# Altium schematic sheet 03_STM32_MCU_POWER.SchDoc(03_STM32_MCU_POWER)
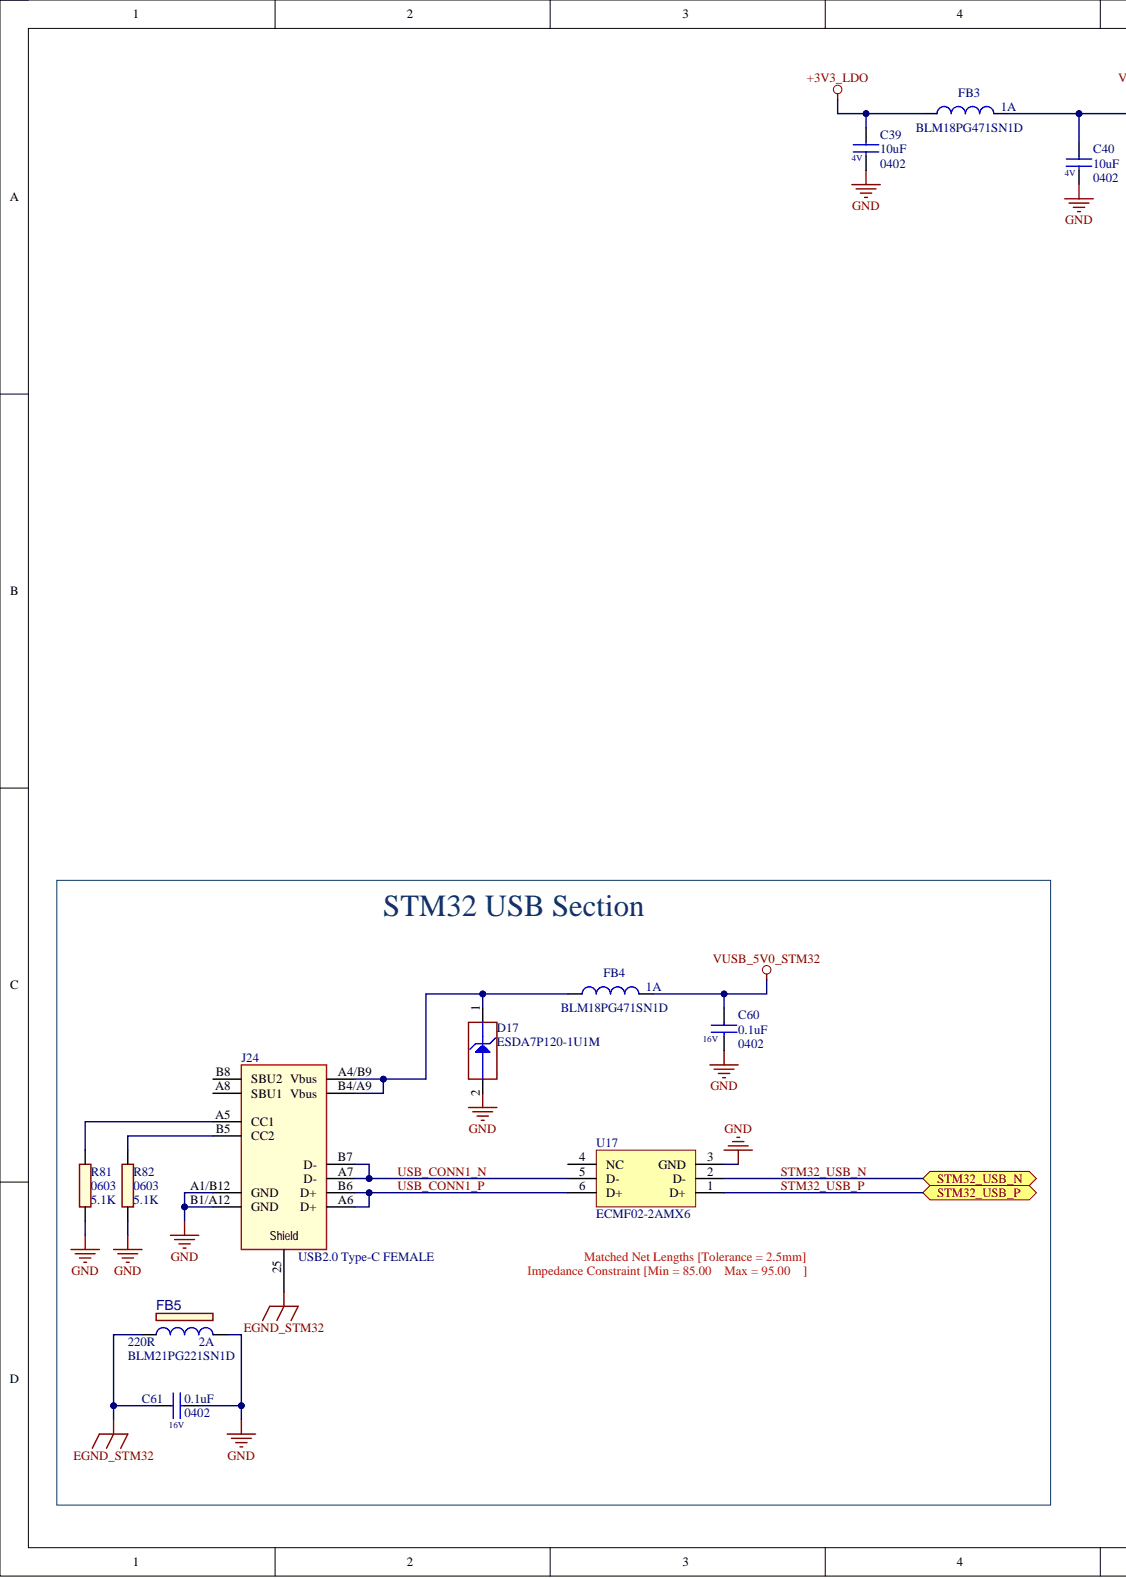
[diagram p0: 03_STM32_MCU_POWER.SchDoc, left]
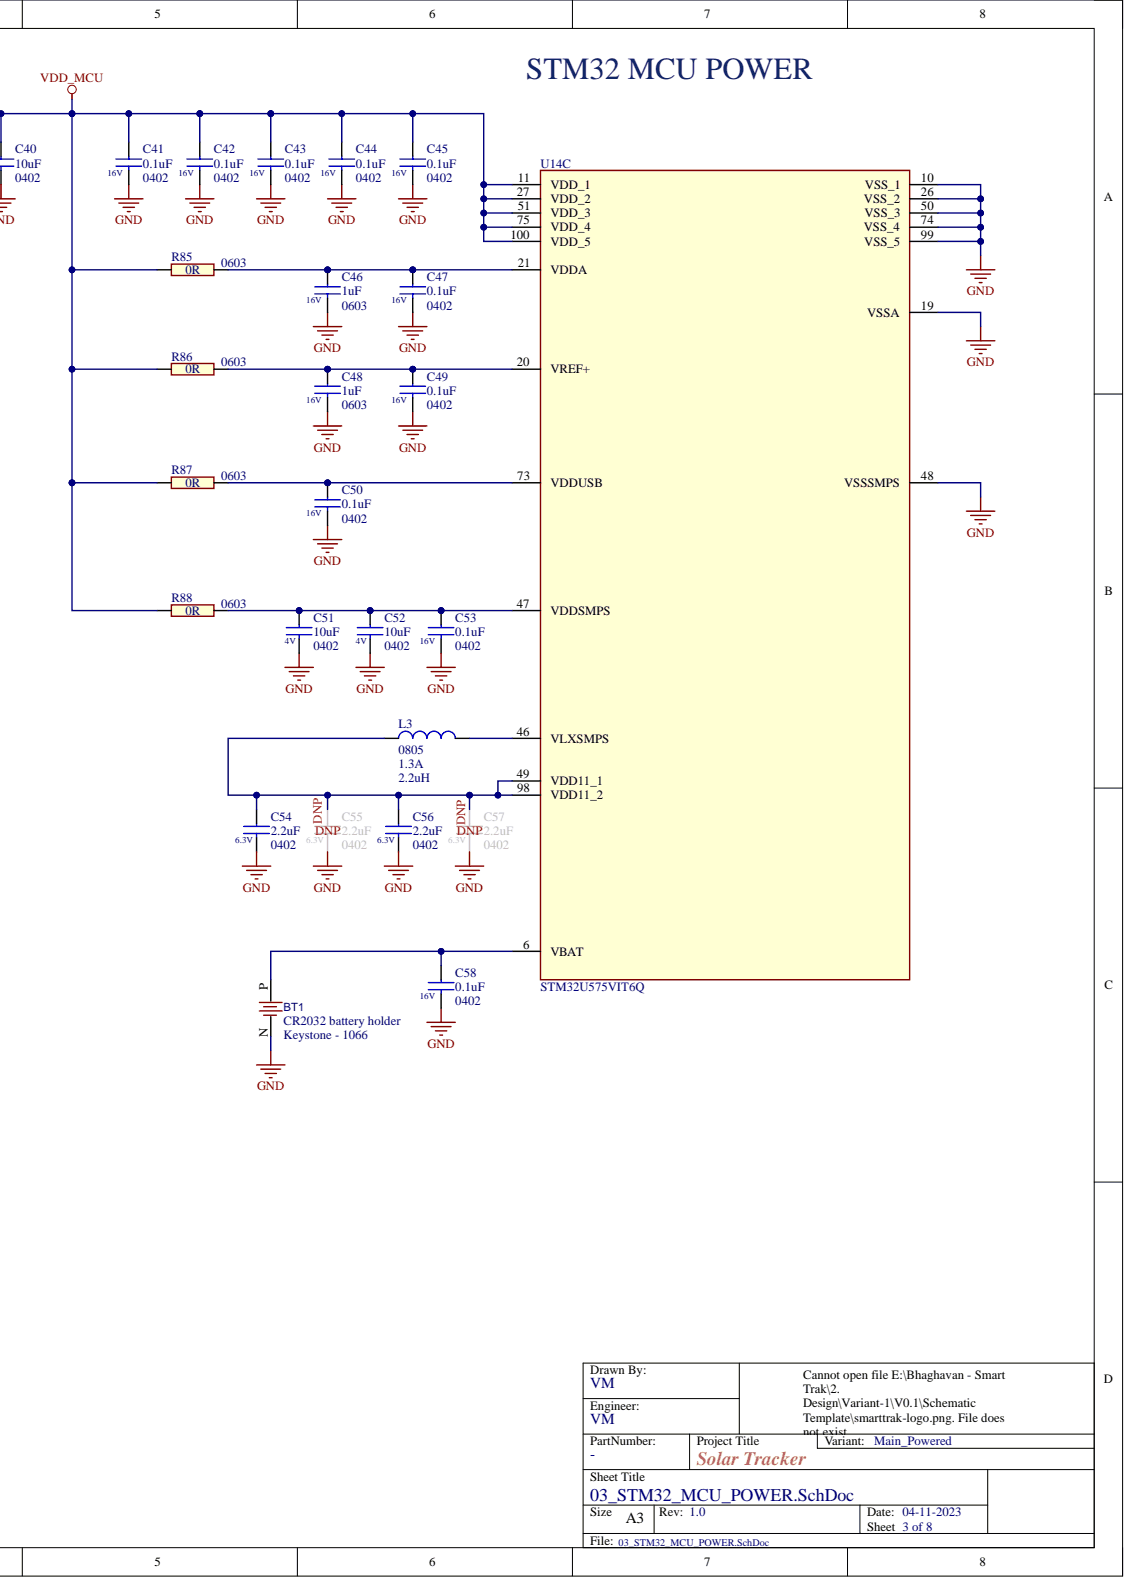
[diagram p1: 03_STM32_MCU_POWER.SchDoc, right]

## Parts
BT1  Keystone_1066  value Keystone - 1066  [BAT_1066]
C39  value 10uF  Note: 0402  Voltage - Rated: 4V  [C0402]  (hidden comment: CAP_10uF_0402_4V)
C40  value 10uF  Note: 0402  Voltage - Rated: 4V  [C0402]  (hidden comment: CAP_10uF_0402_4V)
C41  value 0.1uF  Note: 0402  Voltage - Rated: 16V  [C0402]  (hidden comment: CAP_0.1uF_0402_16V)
C42  value 0.1uF  Note: 0402  Voltage - Rated: 16V  [C0402]  (hidden comment: CAP_0.1uF_0402_16V)
C43  value 0.1uF  Note: 0402  Voltage - Rated: 16V  [C0402]  (hidden comment: CAP_0.1uF_0402_16V)
C44  value 0.1uF  Note: 0402  Voltage - Rated: 16V  [C0402]  (hidden comment: CAP_0.1uF_0402_16V)
C45  value 0.1uF  Note: 0402  Voltage - Rated: 16V  [C0402]  (hidden comment: CAP_0.1uF_0402_16V)
C46  value 1uF  Note: 0603  Voltage - Rated: 16V  [C0805]  (hidden comment: CAP_1uF_0603_16V)
C47  value 0.1uF  Note: 0402  Voltage - Rated: 16V  [C0402]  (hidden comment: CAP_0.1uF_0402_16V)
C48  value 1uF  Note: 0603  Voltage - Rated: 16V  [C0805]  (hidden comment: CAP_1uF_0603_16V)
C49  value 0.1uF  Note: 0402  Voltage - Rated: 16V  [C0402]  (hidden comment: CAP_0.1uF_0402_16V)
C50  value 0.1uF  Note: 0402  Voltage - Rated: 16V  [C0402]  (hidden comment: CAP_0.1uF_0402_16V)
C51  value 10uF  Note: 0402  Voltage - Rated: 4V  [C0402]  (hidden comment: CAP_10uF_0402_4V)
C52  value 10uF  Note: 0402  Voltage - Rated: 4V  [C0402]  (hidden comment: CAP_10uF_0402_4V)
C53  value 0.1uF  Note: 0402  Voltage - Rated: 16V  [C0402]  (hidden comment: CAP_0.1uF_0402_16V)
C54  value 2.2uF  Note: 0402  Voltage - Rated: 6.3V  [C0402]  (hidden comment: CAP_2.2uF_0402_6.3V)
C55  value 2.2uF  Note: 0402  Voltage - Rated: 6.3V  [C0402]  (hidden comment: CAP_2.2uF_0402_6.3V)
C56  value 2.2uF  Note: 0402  Voltage - Rated: 6.3V  [C0402]  (hidden comment: CAP_2.2uF_0402_6.3V)
C57  value 2.2uF  Note: 0402  Voltage - Rated: 6.3V  [C0402]  (hidden comment: CAP_2.2uF_0402_6.3V)
C58  value 0.1uF  Note: 0402  Voltage - Rated: 16V  [C0402]  (hidden comment: CAP_0.1uF_0402_16V)
C60  value 0.1uF  Note: 0402  Voltage - Rated: 16V  [C0402]  (hidden comment: CAP_0.1uF_0402_16V)
C61  value 0.1uF  Note: 0402  Voltage - Rated: 16V  [C0402]  (hidden comment: CAP_0.1uF_0402_16V)
D17  value ESDA7P120-1U1M  MPN: ESDA7P120-1U1M  [DIODE_1610-2A]  (hidden comment: TVS_ESDA7P120-1U1M)
FB3  value BLM18PG471SN1D  Current Rating: 1A  MPN: BLM18PG471SN1D  [FB0603]  (hidden comment: FERRITE_BEAD_BLM18PG471SN1)
FB4  value BLM18PG471SN1D  Current Rating: 1A  MPN: BLM18PG471SN1D  [FB0603]  (hidden comment: FERRITE_BEAD_BLM18PG471SN1)
FB5  value 220R  Current Rating: 2A  MPN: BLM21PG221SN1D  [FB0805]  (hidden comment: Ferrite Bead)
J24  value USB2.0 Type-C FEMALE  [GCT_USB4105-GF-A]  (hidden comment: CON_USB-2.0_TYPE-C_RA)
L3  Current Rating: 1.3A  Note: 0805  [L0805]  (hidden comment: IND_2.2uH_0805)
R81  value 5.1K  Note: 0603  [R0603]  (hidden comment: RES_5.1K_0603)
R82  value 5.1K  Note: 0603  [R0603]  (hidden comment: RES_5.1K_0603)
R85  value 0R  Note: 0603  [R0603]  (hidden comment: RES_0R_0603)
R86  value 0R  Note: 0603  [R0603]  (hidden comment: RES_0R_0603)
R87  value 0R  Note: 0603  [R0603]  (hidden comment: RES_0R_0603)
R88  value 0R  Note: 0603  [R0603]  (hidden comment: RES_0R_0603)
U14  STM32U575VIT6Q  [QFP50P1600X1600X160-100N]  (part C)
U17  value ECMF02-2AMX6  MPN: ECMF02-2AMX6  [DFN50P170X150X60-6N]  (hidden comment: USB_CHOKE_ESD_ECMF02-2AMX6)

## Ports
STM32_USB_N, STM32_USB_P

## Nets
+3V3_LDO: C39.1 FB3.1
EGND_STM32: C61.1 FB5.1 J24.25
GND: BT1.N C39.2 C40.2 C41.2 C42.2 C43.2 C44.2 C45.2 C46.2 C47.2 C48.2 C49.2 C50.2 C51.2 C52.2 C53.2 C54.2 C55.2 C56.2 C57.2 C58.2 C60.2 C61.2 D17.2 FB5.2 J24.A1/B12(GND) J24.B1/A12(GND) R81.1 R82.1 U14.10(VSS_1) U14.19(VSSA) U14.26(VSS_2) U14.48(VSSSMPS) U14.50(VSS_3) U14.74(VSS_4) U14.99(VSS_5) U17.3(GND)
VDD_MCU: C40.1 C41.1 C42.1 C43.1 C44.1 C45.1 FB3.2 R85.1 R86.1 R87.1 R88.1 U14.11(VDD_1) U14.27(VDD_2) U14.51(VDD_3) U14.75(VDD_4) U14.100(VDD_5)
VUSB_5V0_STM32: C60.1 FB4.2
STM32_USB_N: U17.2(D-) ; port STM32_USB_N
STM32_USB_P: U17.1(D+) ; port STM32_USB_P
USB_CONN1_N: J24.A7(D-) J24.B7(D-) U17.5(D-)
USB_CONN1_P: J24.A6(D+) J24.B6(D+) U17.6(D+)
NetBT1_P: BT1.P C58.1 U14.6(VBAT)
NetC46_1: C46.1 C47.1 R85.2 U14.21(VDDA)
NetC48_1: C48.1 C49.1 R86.2 U14.20(VREF+)
NetC50_1: C50.1 R87.2 U14.73(VDDUSB)
NetC51_1: C51.1 C52.1 C53.1 R88.2 U14.47(VDDSMPS)
NetC54_1: C54.1 C55.1 C56.1 C57.1 L3.1 U14.49(VDD11_1) U14.98(VDD11_2)
NetD17_1: D17.1 FB4.1 J24.A4/B9(Vbus) J24.B4/A9(Vbus)
NetJ24_A5: J24.A5(CC1) R81.2
NetJ24_B5: J24.B5(CC2) R82.2
NetL3_2: L3.2 U14.46(VLXSMPS)

Not connected: J24 A8(SBU1) B8(SBU2); U17 4(NC)

## Library data of the parts
BT1 = Keystone_1066: BATT HLDR COIN 20MM 1 CEL PC PIN; Manufacturer: Keystone Electronics; MPN: 1066; Note: CR2032 battery holder; Component Kind: Standard; Library Name: Project.SchLib
C39 C40 C51 C52 = CAP_10uF_0402_4V: CAP CER 10UF 4V X5R 0402; Manufacturer: Yageo; MPN: CC0402MRX5R4BB106; Component Kind: Standard; Library Name: Project.SchLib
C41-C45 C47 C49 C50 C53 C58 C60 C61 = CAP_0.1uF_0402_16V: CAP CER 0.1UF 16V X7R 0402; Manufacturer: Yageo; MPN: CC0402KRX7R7BB104; Component Kind: Standard; Library Name: Project.SchLib
C46 C48 = CAP_1uF_0603_16V: CAP CER 1UF 16V X5R 0603; Manufacturer: Yageo; MPN: CC0603KRX5R7BB105; Component Kind: Standard; Library Name: Project.SchLib
C54-C57 = CAP_2.2uF_0402_6.3V: CAP CER 2.2UF 6.3V X5R 0402; Manufacturer: KEMET; MPN: GRM153R60J225ME95D; Component Kind: Standard; Library Name: Project.SchLib
D17 = TVS_ESDA7P120-1U1M: TVS DIODE 5.5VWM 11.5VC 1610; Manufacturer: STMICROELECTRONICS; Component Kind: Standard; Library Name: Project.SchLib; Note: -
FB3 FB4 = FERRITE_BEAD_BLM18PG471SN1: FERRITE BEAD 470 OHM 0603 1LN; Manufacturer: Murata Electronics; Component Kind: Standard; Library Name: Project.SchLib; Note: -
FB5 = FERRITE_BEAD_BLM21PG221SN1D: FERRITE BEAD 220 OHM 0805 1LN; Manufacturer: Murata; Component Kind: Standard; ComponentLink5Description: Open Footprint 2; ComponentLink6Description: Open Footprint 3; ComponentLink7Description: Open Footprint 4; Library Name: Project.SchLib; Note: BLM21PG221SN1D
J24 = CON_USB-2.0_TYPE-C_RA: CONN RCP USB2.0 TYP C 24P SMD RA; Manufacturer: GCT; MPN: USB4105-GF-A; Component Kind: Standard; ComponentLink5Description: Open Footprint 2; ComponentLink6Description: Open Footprint 3; ComponentLink7Description: Open Footprint 4; Library Name: Project.SchLib; Note: USB4105-GF-A
L3 = IND_2.2uH_0805: FIXED IND 2.2UH 1.3A 156MOHM SMD 0805; Manufacturer: Murata Electronics; Value: LQM21PN2R2MGHL; Component Kind: Standard; Library Name: Project.SchLib; MPN: 2.2uH
R81 R82 = RES_5.1K_0603: RES 5.1K OHM 5% 1/10W 0603; Manufacturer: Yageo; MPN: RC0603JR-075K1L; Component Kind: Standard; Library Name: Project.SchLib
R85-R88 = RES_0R_0603: RES SMD 0 OHM JUMPER 1/10W 0603; Manufacturer: Yageo; MPN: RC0603JR-070RL; Component Kind: Standard; Library Name: Project.SchLib
U14 = STM32U575VIT6Q: Ultra-low-power with FPU Arm Cortex-M33 with Trust Zone, MCU 160 MHz with 2Mbytes of Flash memory; Manufacturer: STMicroelectronics; Component Kind: Standard; Library Name: Project.SchLib; MPN: STM32U575VIT6Q; Value: STM32U575VIT6Q
U17 = USB_CHOKE_ESD_ECMF02-2AMX6: CMC 200MA 2LN SMD ESD; Manufacturer: STMicroelectronics; Component Kind: Standard; Library Name: Project.SchLib
